# Altium schematic sheet Main.SchDoc
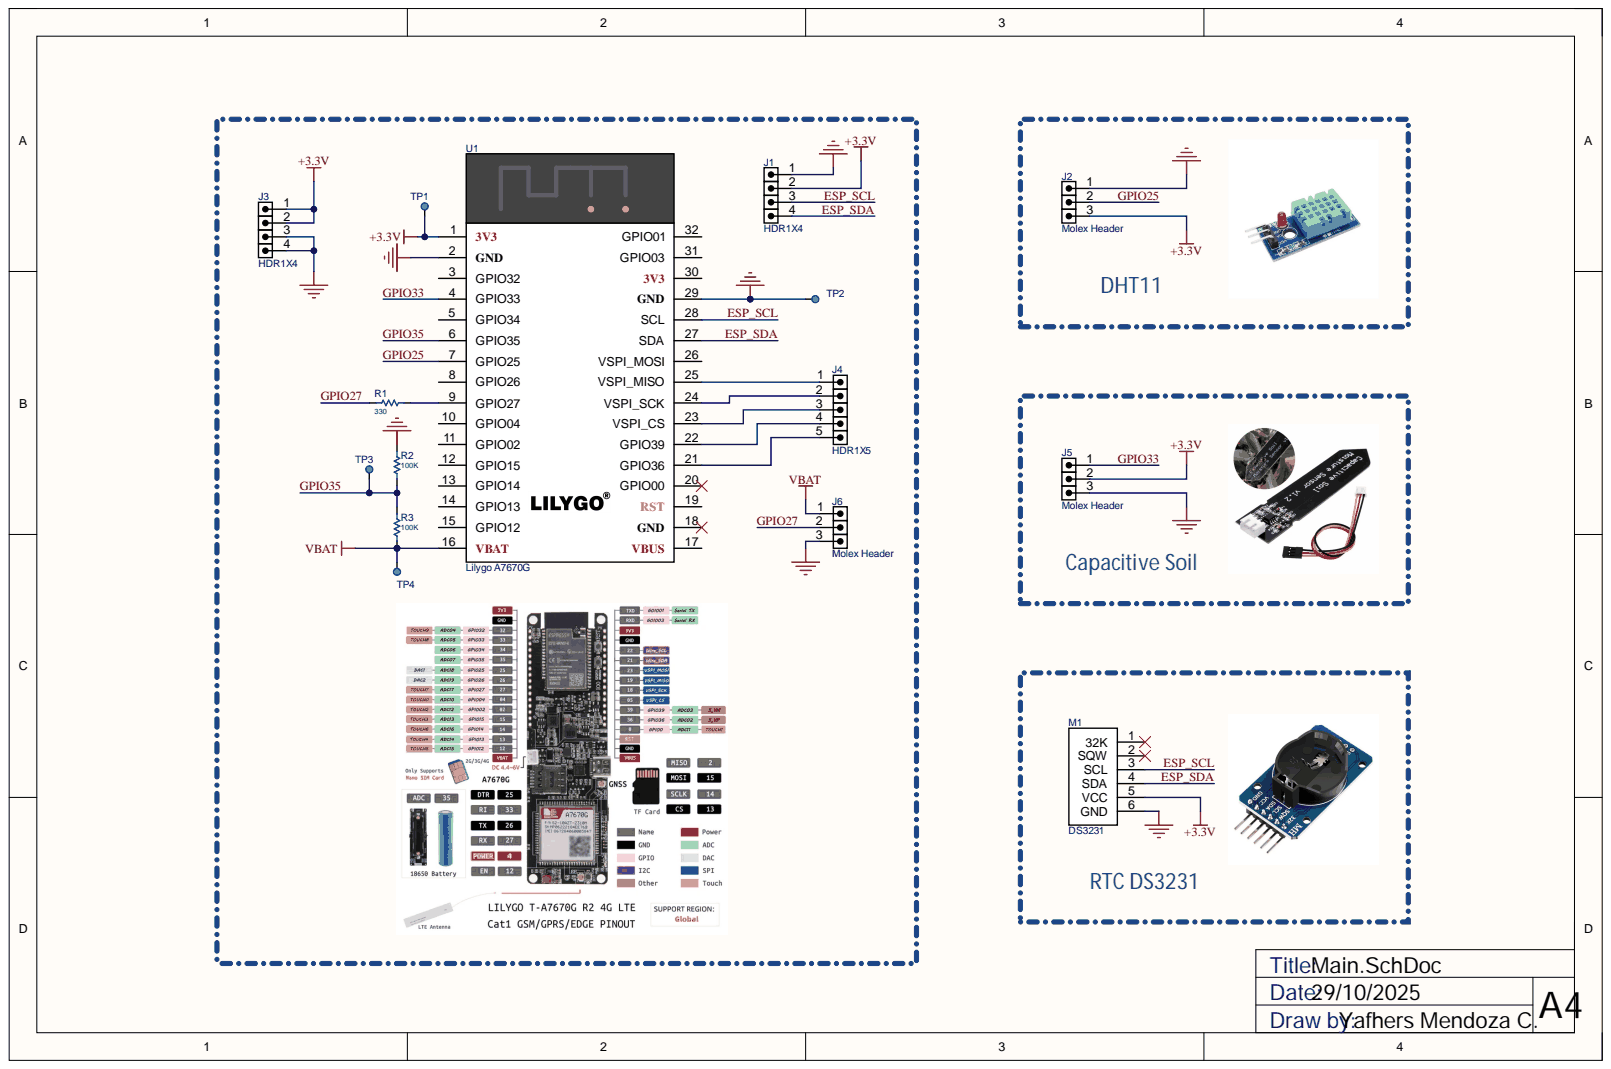
[diagram p0: Main.SchDoc]

## Parts
J1  HDR1X4  [HDR_M_1X4]
J2  Molex Header  [MOLEX_3P_V]
J3  HDR1X4  [HDR_M_1X4]
J4  HDR1X5  [HDR_M_1X5]
J5  Molex Header  [MOLEX_3P_V]
J6  Molex Header  [MOLEX_3P_V]
M1  DS3231  [DS3231]
R1  330  [RES_0.250W]
R2  100K  [RES_0.250W]
R3  100K  [RES_0.250W]
TP1  TestPoint  [TEST_POINT]  (value not drawn)
TP2  TestPoint  [TEST_POINT]  (value not drawn)
TP3  TestPoint  [TEST_POINT]  (value not drawn)
TP4  TestPoint  [TEST_POINT]  (value not drawn)
U1  Lilygo A7670G  [LILYGO-A7670G]

## Nets
+3.3V: J1.2 J2.3 J3.1 J3.2 J5.2 M1.5(VCC) TP1.1 U1.1(3V3)
GND: J1.1 J2.1 J3.3 J3.4 J5.3 J6.3 M1.6(GND) R2.1 TP2.1 U1.2(GND) U1.29(GND)
VBAT: J6.1 R3.2 TP4.1 U1.16(VBAT)
ESP_SCL: J1.3 M1.3(SCL) U1.28(SCL)
ESP_SDA: J1.4 M1.4(SDA) U1.27(SDA)
GPIO25: J2.2 U1.7(GPIO25)
GPIO27: J6.2 R1.1
GPIO33: J5.1 U1.4(GPIO33)
GPIO35: R2.2 R3.1 TP3.1 U1.6(GPIO35)
NetJ4_1: J4.1 U1.25(VSPI_MISO)
NetJ4_2: J4.2 U1.24(VSPI_SCK)
NetJ4_3: J4.3 U1.23(VSPI_CS)
NetJ4_4: J4.4 U1.22(GPIO39)
NetJ4_5: J4.5 U1.21(GPIO36)
NetR1_2: R1.2 U1.9(GPIO27)

Not connected: M1 1(32K) 2(SQW); U1 3(GPIO32) 5(GPIO34) 8(GPIO26) 10(GPIO04) 11(GPIO02) 12(GPIO15) 13(GPIO14) 14(GPIO13) 15(GPIO12) 17(VBUS) 18(GND) 19(RST) 20(GPIO00) 26(VSPI_MOSI) 30(3V3) 31(GPIO03) 32(GPIO01)

## Library data of the parts
J1 J3 = Espadin_M_1x04: CONN, HEADER, Male, pitch: 2.54mm, 4POS; VALUE: HDRM4; Component Kind: Standard; Library Name: Conectores.IntLib
J2 J5 J6 = Molex_3pin: Component Kind: Standard; Description: Molex Header; Library Name: Conectores.IntLib
J4 = Espadin_M_1x05: CONN, HEADER, Male, pitch: 2.54mm, 5POS; VALUE: HDRM5; Component Kind: Standard; Library Name: Conectores.IntLib
M1 = DS3231: Modulo RTC DS3231; Component Kind: Standard; Library Name: Modulos.IntLib
R1-R3 = RES_THD: Resistor THD; Component Kind: Standard; Library Name: Pasivos.IntLib
  R1: VALUE: 330
  R2 R3: VALUE: 100K
TP1-TP4 = TestPoint: Punto de prueba de señales; Component Kind: Standard; Library Name: Conectores.IntLib
U1 = Lilygo-A7670G: Component Kind: Standard; Description: Lilygo A7670G; Library Name: Microcontroladores.IntLib
